# Altium schematic sheet Arduino NANO Adaptor 2-21.SchDoc("Arduino NANO Adaptor 2-21")
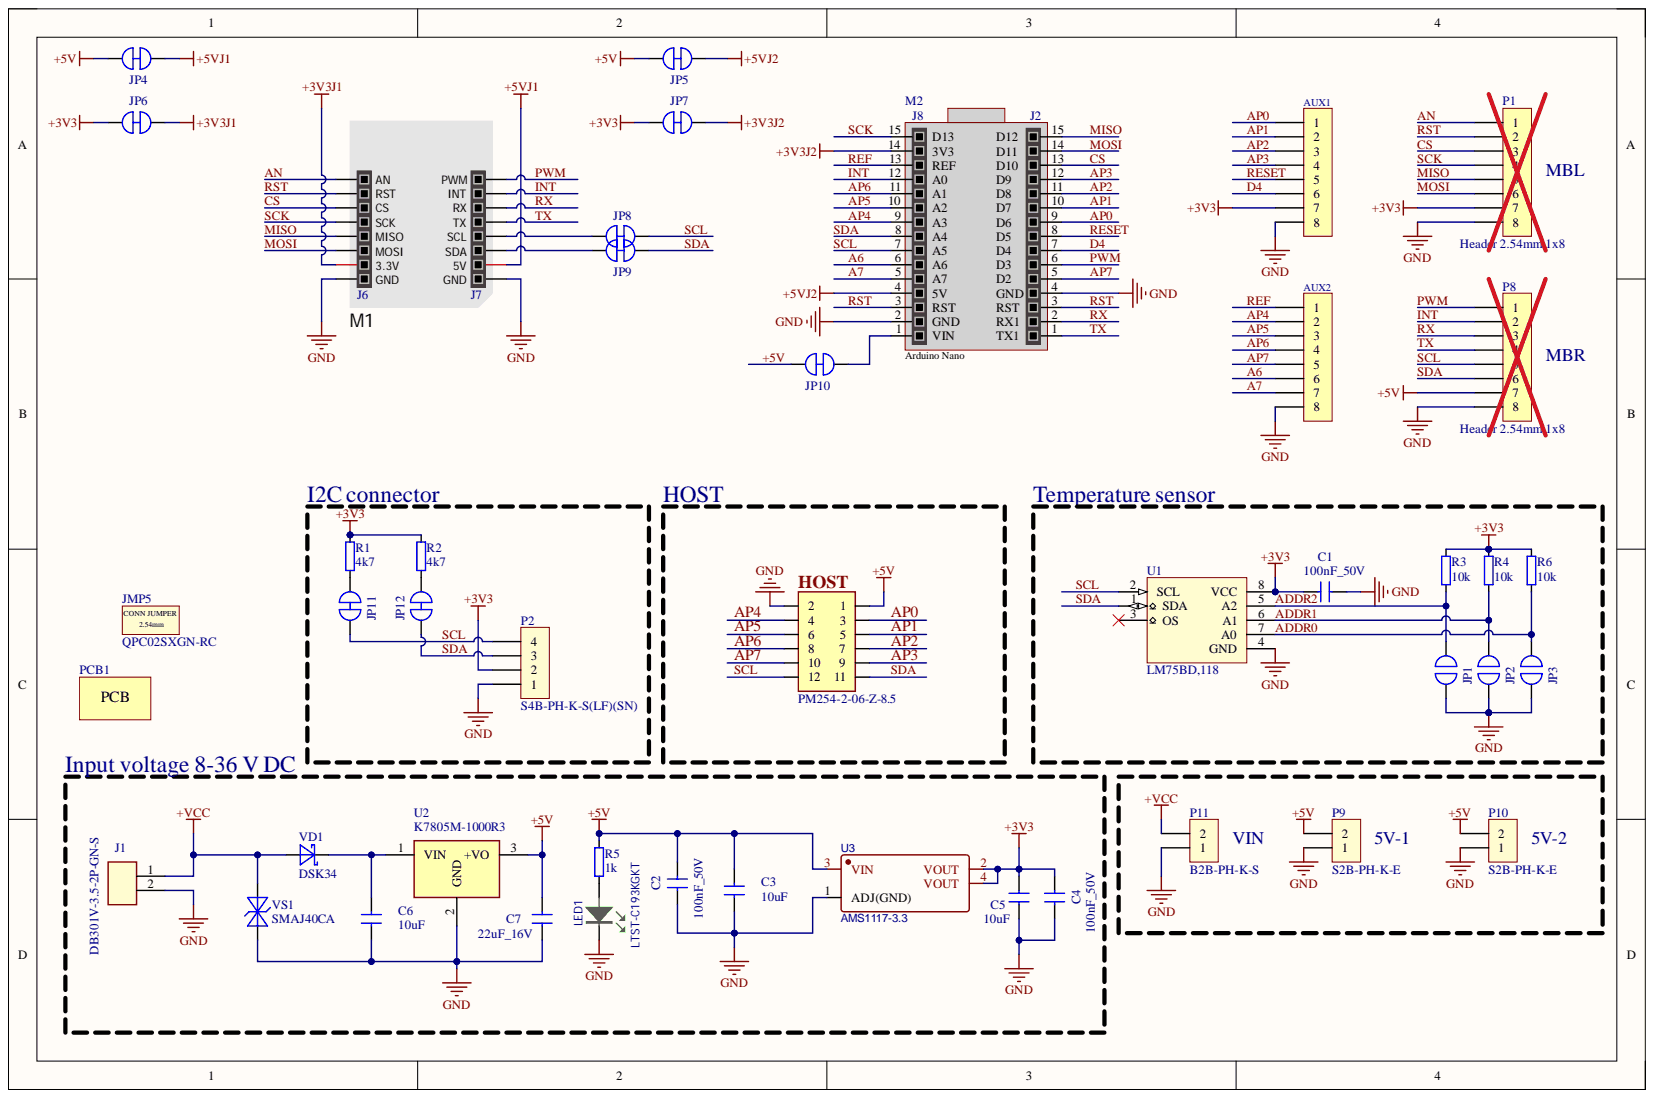
[diagram p0: Arduino NANO Adaptor 2-21.SchDoc]

## Parts
AUX1  PLS-8  [XP-8 (2.54mm, PLS-8) - 1]  (value not drawn)
AUX2  PLS-8  [XP-8 (2.54mm, PLS-8) - 1]  (value not drawn)
C1  100nF_50V  [C0603]
C2  100nF_50V  [C0603]
C3  10uF  [C0603]
C4  100nF_50V  [C0603]
C5  10uF  [C0603]
C6  10uF  [C0603]
C7  22uF_16V  [C0603]
HOST  PM254-2-06-Z-8.5  [PBD-12]
J1  DB301V-3.5-2P-GN-S  [CONN-TH_DB301V-3.5-2P-GN]
J2  PBS-15  [XP-15 (2.54mm, PBS-15)]  (value not drawn)
J6  SSQ-108-01-T-S  [MIKROE_ROW_1x8]  (value not drawn)
J7  SSQ-108-01-T-S  [MIKROE_ROW_1x8]  (value not drawn)
J8  PBS-15  [XP-15 (2.54mm, PBS-15)]  (value not drawn)
JMP5  QPC02SXGN-RC  (mechanical)
JP1  SOLDER_JUMPER_NO  [SOLDER_JUMPER_NO]  (value not drawn)
JP2  SOLDER_JUMPER_NO  [SOLDER_JUMPER_NO]  (value not drawn)
JP3  SOLDER_JUMPER_NO  [SOLDER_JUMPER_NO]  (value not drawn)
JP4  SOLDER_JUMPER_NC  [SOLDER_JUMPER_NC]  (value not drawn)
JP5  SOLDER_JUMPER_NC  [SOLDER_JUMPER_NC]  (value not drawn)
JP6  SOLDER_JUMPER_NC  [SOLDER_JUMPER_NC]  (value not drawn)
JP7  SOLDER_JUMPER_NC  [SOLDER_JUMPER_NC]  (value not drawn)
JP8  SOLDER_JUMPER_NC  [SOLDER_JUMPER_NC]  (value not drawn)
JP9  SOLDER_JUMPER_NC  [SOLDER_JUMPER_NC]  (value not drawn)
JP10  SOLDER_JUMPER_NC  [SOLDER_JUMPER_NC]  (value not drawn)
JP11  SOLDER_JUMPER_NC  [SOLDER_JUMPER_NC]  (value not drawn)
JP12  SOLDER_JUMPER_NC  [SOLDER_JUMPER_NC]  (value not drawn)
LED1  LTST-C193KGKT  [LED-0603]
M1  MIKROBUS HOST  [MIKROBUS HOST CONN.]  (value not drawn)  (no BOM)
M2  Arduino Nano  Brand: Arduino  [Socket_Arduino Nano]
P1  Header 2.54mm 1x8  [CONN_Header_2.54mm_1X8]
P2  S4B-PH-K-S(LF)(SN)  [Conn_S4B-PH-K-S]
P8  Header 2.54mm 1x8  [CONN_Header_2.54mm_1X8]
P9  S2B-PH-K-E  [Conn_S2B-PH-K-E]
P10  S2B-PH-K-E  [Conn_S2B-PH-K-E]
P11  B2B-PH-K-S  [Conn B2B-PH-K-S]
PCB1  PCB  (mechanical)
R1  4k7  [R-0603]
R2  4k7  [R-0603]
R3  10k  [R-0603]
R4  10k  [R-0603]
R5  1k  [R-0603]
R6  10k  [R-0603]
U1  LM75BD,118  [SOIC-8]
U2  K7805M-1000R3  [CONV_K7805M-1000R3]
U3  AMS1117-3.3  [SOT-223-3_L6.5-W3.4-P2.30-LS7.0-BR]
VD1  DSK34  [SOD-123]
VS1  SMAJ40CA  [SMAJ_BI]

## Nets
+3V3: AUX1.7 C1.1 C4.2 C5.2 JP6.1 JP7.1 P1.7 P2.2 R1.2 R2.2 R3.2 R4.2 R6.2 U1.8 U3.2 U3.4
+3V3J1: J6.7 JP6.2
+3V3J2: J8.14 JP7.2
+5VJ1: J7.7 JP4.2
+5VJ2: J8.4 JP5.2
+VCC: J1.1 P11.2 VD1.1 VS1.2
GND: AUX1.8 AUX2.8 C1.2 C2.1 C3.1 C4.1 C5.1 C6.1 C7.1 HOST.2 J1.2 J2.4 J6.8 J7.8 J8.2 JP1.2 JP2.2 JP3.2 LED1.2 P1.8 P2.1 P8.8 P9.1 P10.1 P11.1 U1.4 U2.2 U3.1 VS1.1
+5V: C2.2 C3.2 C7.2 HOST.1 JP4.1 JP5.1 JP10.1 P8.7 P9.2 P10.2 R5.2 U2.3 U3.3
A6: AUX2.6 J8.6
A7: AUX2.7 J8.5
ADDR0: JP3.1 R6.1 U1.7
ADDR1: JP2.1 R4.1 U1.6
ADDR2: JP1.1 R3.1 U1.5
AN: J6.1 P1.1
AP0: AUX1.1 HOST.3 J2.9
AP1: AUX1.2 HOST.5 J2.10
AP2: AUX1.3 HOST.7 J2.11
AP3: AUX1.4 HOST.9 J2.12
AP4: AUX2.2 HOST.4 J8.9
AP5: AUX2.3 HOST.6 J8.10
AP6: AUX2.4 HOST.8 J8.11
AP7: AUX2.5 HOST.10 J2.5
CS: J2.13 J6.3 P1.3
D4: AUX1.6 J2.7
INT: J7.2 J8.12 P8.2
MISO: J2.15 J6.5 P1.5
MOSI: J2.14 J6.6 P1.6
PWM: J2.6 J7.1 P8.1
REF: AUX2.1 J8.13
RESET: AUX1.5 J2.8
RST: J2.3 J6.2 J8.3 P1.2
RX: J2.2 J7.3 P8.3
SCK: J6.4 J8.15 P1.4
SCL: HOST.12 J8.7 JP8.2 JP11.1 P2.4 P8.5 U1.2
SDA: HOST.11 J8.8 JP9.2 JP12.1 P2.3 P8.6 U1.1
TX: J2.1 J7.4 P8.4
NetC6_2: C6.2 U2.1 VD1.2
NetJ7_5: J7.5 JP8.1
NetJ7_6: J7.6 JP9.1
NetJ8_1: J8.1 JP10.2
NetJP11_2: JP11.2 R1.1
NetJP12_2: JP12.2 R2.1
NetLED1_1: LED1.1 R5.1

Not connected: U1 3

## Library data of the parts
AUX1 AUX2 = XP_Header 8-1: Header, 15-Pin; PartNumber: DS1021-1*8-SF11-B; Brand: Connfly; Class I: Connector; Encapsulation: THT-8; Remark: or analog PLS-8; Specification: DS1021-1*8S; Value: 1x8pin, P2.54mm; Component Kind: Standard; Library Name: My.SCHLIB; Signal Integrity: Connector
C1 C2 C4 = C1608X7R1H104KT000N: 100nF 50V X7R ±10% 0603 Multilayer Ceramic Capacitors MLCC - SMD/SMT ROHS; Component Kind: Standard; LCSC #: C56476; Library Name: Bokra.SCHLIB
C3 C5 C6 = C0603_10U: Component Kind: Standard; Description: C0603_10U; Library Name: Bokra.SCHLIB
C7 = GRM188C61C226ME01J: CAP CER 22UF 16V X5S 0603; Component Kind: Standard; Library Name: Bokra.SCHLIB
HOST = DS1023-2x6SF11: 2.54mm Female Header  V/T Type, 2x06 Pin; Component Kind: Standard; Value: PM254-2-06-Z-8.5; Library Name: IoTextra_Input_2-03.SCHLIB
J1 = DB301V-3.5-2P-GN-S: TERM BLK 2POS 3.5mm TH; Manufacturer: DORABO; Component Kind: Standard; LCSC Part #: C695629; Value: DB301V-3.5-2P-GN-S; Library Name: Bokra.SCHLIB
J2 J8 = XP_Header 15_1: PBS Row Connector; Brand: Connfly; Encapsulation: THT-15; Ordering code: DS1023-01-1*15-SF11; Remark: or analog PBS-15; Value: 1x15pin, P2.54mm; Class I: Connector; Component Kind: Standard; Library Name: My.SCHLIB; Revision: July-2002: Re-released for DXP Platform.; Signal Integrity: Connector; Specification: DS1023-01-1*15-SF11
J6 J7 = MIKROE_ROW_01X08: Manufacturer: Samtec; Component Kind: Standard; Description: SSQ-108-01-T-S; Library Name: Connectors.SchLib; Manufacturer Part Number: SSQ-108-01-T-S; PartNumber: SSQ-108-01-T-S; Supplier 1: Mouser; Supplier Link 1: https://www.mouser.at/ProductDetail/Samtec/SSQ-108-01-T-S?qs=sGAEpiMZZMs%252bGHln7q6pm8Vn94ktop%2fJQMA9o%252bSmScjSBLV7TdKl6g==; Supplier Part Number 1: 200-SSQ10801TS
JMP5 = Conn Jumper 2.54mm: CONN JUMPER SHORTING .100" GOLD; Manufacturer: Sullins Connector Solutions; Library Name: BL_Mechanical.SchLib; Manufacturer Part Number: QPC02SXGN-RC; Supplier 1: Digi-Key; Supplier Part Number 1: S9337-ND
JP1-JP3 = SOLDER_JUMPER_NO: Solder Jumper; Component Kind: Net Tie; Library Name: Bokra.SCHLIB
JP4-JP12 = SOLDER_JUMPER_NC: Solder Jumper; Component Kind: Net Tie; Library Name: Bokra.SCHLIB
LED1 = LTST-C193KGKT: Standard LEDs - SMD Green, 571nm 12mcd, 5mA; Manufacturer: LiteON; Manufacturer Part Number: LTST-C193KGKT-5A; Fixed: NO; Component Kind: Standard; Library Name: LEDs.IntLib; Mounted: YES; PartNumber: LTST-C193KGKT-5A; Supplier 1: Mouser; Supplier Link 1: http://www.mouser.at/ProductDetail/Lite-On/LTST-C193KGKT-5A/?qs=%2fha2pyFaduj%252bwTWyfQGTE6Xg7B2sI2cG%252b%252bq3NWSFTtmxnIwkCmyoYQ%3d%3d; Supplier Part Number 1: 859-LTSTC193KGKT5A
M1 = MIKROBUS HOST: mikroBUS Host Socket; Library Name: Connectors.SchLib
M2 = Socket Arduino Nano: Class I: Power Management; Encapsulation: TNT-30; Ordering code: DS1023-01-1*15-SF11; Specification: 2xPBS-15; Value: P2.54mm; Component Kind: Standard; Description: Socket Arduino Nano; Remark: Socket Arduino Nano; Library Name: Bokra.SCHLIB
P1 P8 = Conn Header 2.54mm 1X8: 2.54mm pitch 8 pin (1x8) top entry wire to board connector THT; Manufacturer: Sullins Connector Solutions; Manufacturer Part Number: PREC008SAAN-RC; Component Kind: Standard; Supplier 1: Digi-Key; Supplier Part Number 1: S1012EC-08-ND; Library Name: Tobias_Mechanical.SchLib
P2 = Conn S4B-PH-K-S(LF)(SN): 2mm pitch 4 pin side entry wire to board connector THT; Manufacturer: JST; Component Kind: Standard; Manufacturer Part Number: S4B-PH-K-S(LF)(SN); Supplier 1: Digi-Key; Supplier Part Number 1: 455-1721-ND; Library Name: Tobias_Mechanical.SchLib
P9 P10 = Conn S2B-PH-K-E: 2.00mm pitch 2 pin side entry wire to board connector, THT; Manufacturer: JST; Component Kind: Standard; Manufacturer Part Number: S2B-PH-K-E; Library Name: Tobias_Mechanical.SchLib
P11 = Conn B2B-PH-K-S: 2.00mm pitch 2 pin top entry wire to board connector, THT; Manufacturer: JST; Component Kind: Standard; Library Name: BL_Mechanical.SchLib; Manufacturer Part Number: B2B-PH-K-S; Supplier 1: Digi-Key; Supplier Part Number 1: 455-1704-ND
PCB1 = PCB: Printed Circuit Board; Manufacturer: Byte Lab; Library Name: BL_Mechanical.SchLib
R1 R2 = R0603-4k7-1: Resistor 4k7 ±1% 0603; Component Kind: Standard; Library Name: BL_Passive.SchLib; Manufacturer: N/A; Manufacturer Part Number: N/A; Supplier 1: Digi-Key; Supplier Part Number 1: 311-4.70KHRCT-ND
R3 R4 R6 = R0603-10k-1: Resistor 10k ±1% 0603; Component Kind: Standard; Library Name: BL_Passive.SchLib; Manufacturer: N/A; Manufacturer Part Number: N/A; Supplier 1: Digi-Key; Supplier Part Number 1: 311-10.0KHRCT-ND
R5 = R0603-1k-1: Resistor 1k ±1% 0603; Component Kind: Standard; Library Name: BL_Passive.SchLib; Manufacturer: N/A; Manufacturer Part Number: N/A; Supplier 1: Digi-Key; Supplier Part Number 1: 311-1.00KHRCT-ND
U1 = LM75BD,118: Digital temperature sensor and thermal watchdog; Manufacturer: NXP; Component Kind: Standard; LCSC Part #: C34565; Manufacturer Part Number: LM75BD,118; Library Name: Bokra.SCHLIB
U2 = K7805-2000R3: 5V 1000mA 7V~36V 5W DC-DC 94% SIP-3 Power Modules ROHS; Manufacturer: YLPTEC; Component Kind: Standard; Manufacturer Part Number: K7805M-1000R3; Supplier 1: LCSC; Supplier Part Number 1: C5369649; Library Name: IoTbase B+ 3-01.SCHLIB
U3 = AMS1117-3.3_C2891839: SOT-223 Linear Voltage Regulators (LDO) ROHS; Manufacturer: GUOXIN JIAPIN SEMICONDUCTOR; Component Kind: Standard; Library Name: RP2040TR7.SchLib; Manufacturer Part Number: AMS1117-3.3; Supplier 1: LCSC; Supplier Part Number 1: C2992570
VD1 = DSK34: 40V Single 3A 550mV@3A SOD-123 Schottky Barrier Diodes (SBD) ROHS; Manufacturer: KUU; Component Kind: Standard; Manufacturer Part Number: DSK34; Supplier 1: LCSC; Supplier Part Number 1: C3015221; Value: DSK34; Library Name: IoTextra_Input_2-03.SCHLIB
VS1 = SMAJxxCA: 64.5V 44.4V 40V SMA(DO-214AC) TVS ROHS; Manufacturer: BORN; Component Kind: Standard; Manufacturer Part Number: SMAJ40CA; PartNumber1: SMAJ40CA; Supplier 1: LCSC; Supplier Part Number 1: C151933; Value: SMAJ40CA; Library Name: IoTextra_Input_2-03.SCHLIB
